# Altium schematic sheet cny70.SchDoc(cny70)
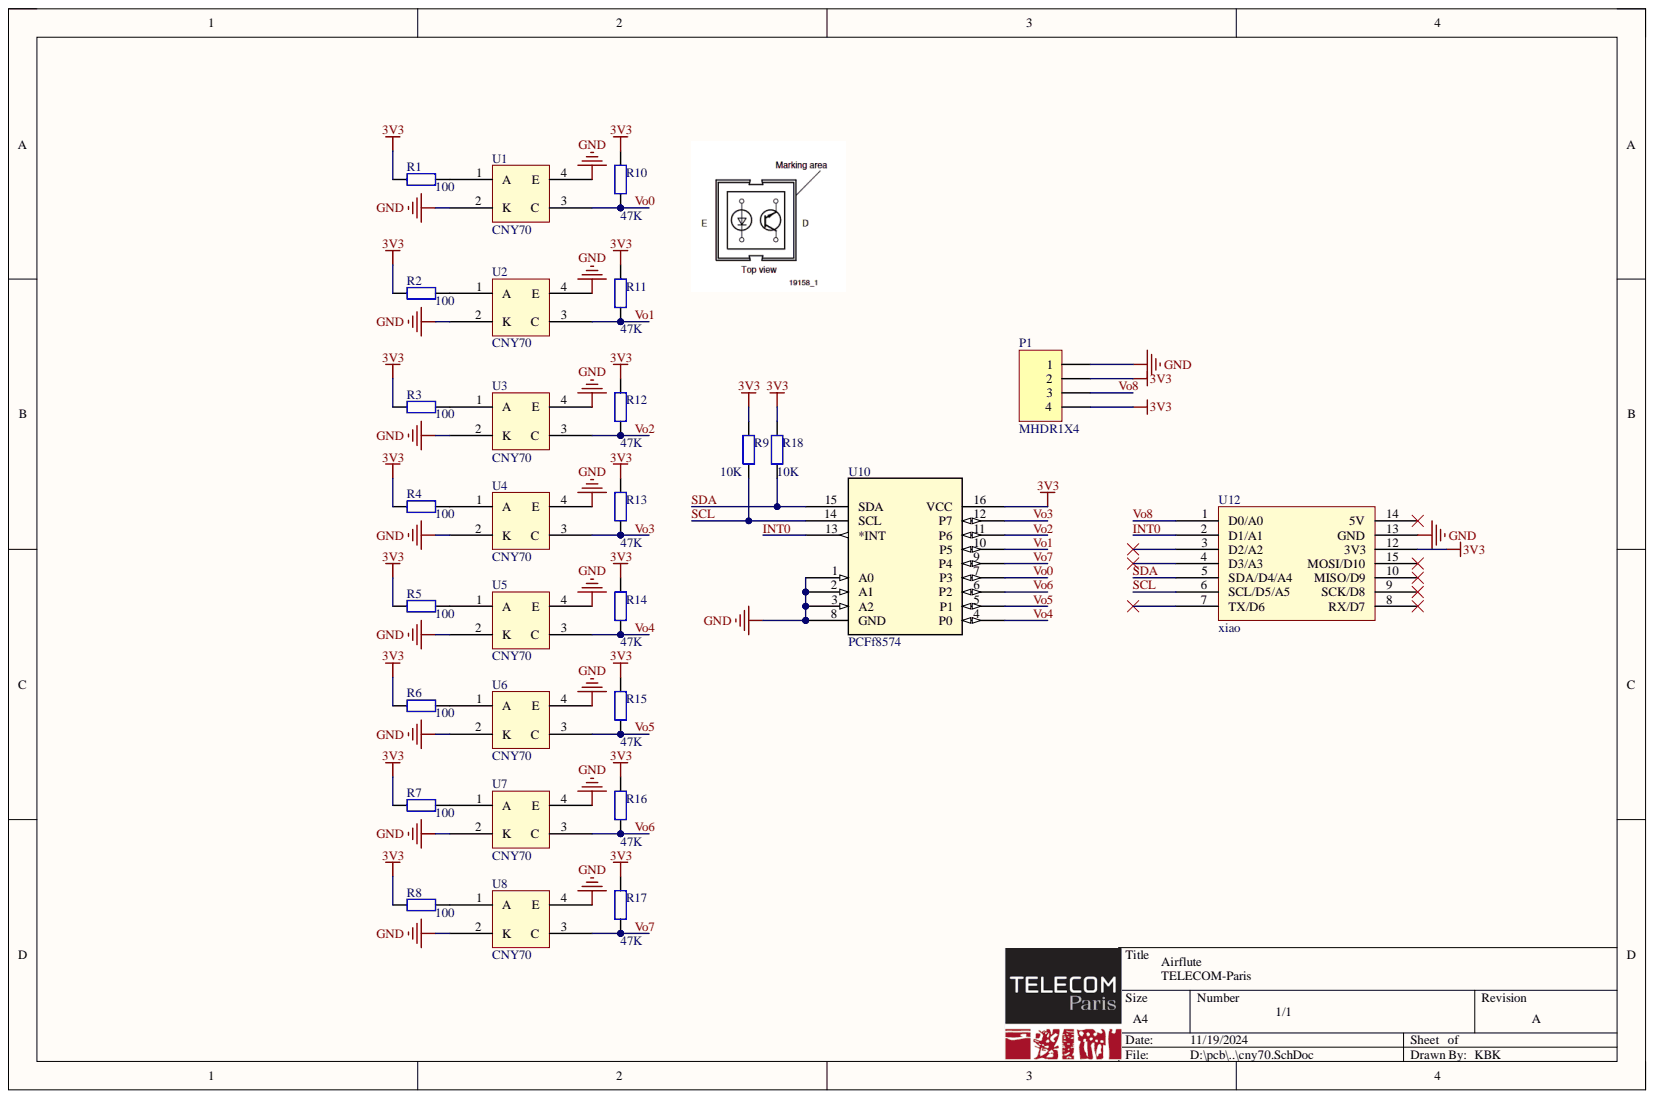
[diagram p0: cny70.SchDoc]

## Parts
P1  MHDR1X4  [HDR1X4]
R1  value 100  [6-0805_L]  (hidden comment: Res100)
R2  value 100  [6-0805_L]  (hidden comment: Res100)
R3  value 100  [6-0805_L]  (hidden comment: Res100)
R4  value 100  [6-0805_L]  (hidden comment: Res100)
R5  value 100  [6-0805_L]  (hidden comment: Res100)
R6  value 100  [6-0805_L]  (hidden comment: Res100)
R7  value 100  [6-0805_L]  (hidden comment: Res100)
R8  value 100  [6-0805_L]  (hidden comment: Res100)
R9  value 10K  [6-0805_L]  (hidden comment: Res10k)
R10  value 47K  [6-0805_L]  (hidden comment: Res4.7k)
R11  value 47K  [6-0805_L]  (hidden comment: Res4.7k)
R12  value 47K  [6-0805_L]  (hidden comment: Res4.7k)
R13  value 47K  [6-0805_L]  (hidden comment: Res4.7k)
R14  value 47K  [6-0805_L]  (hidden comment: Res4.7k)
R15  value 47K  [6-0805_L]  (hidden comment: Res4.7k)
R16  value 47K  [6-0805_L]  (hidden comment: Res4.7k)
R17  value 47K  [6-0805_L]  (hidden comment: Res4.7k)
R18  value 10K  [6-0805_L]  (hidden comment: Res10k)
U1  CNY70  [ncy70]
U2  CNY70  [ncy70]
U3  CNY70  [ncy70]
U4  CNY70  [ncy70]
U5  CNY70  [ncy70]
U6  CNY70  [ncy70]
U7  CNY70  [ncy70]
U8  CNY70  [ncy70]
U10  PCFf8574  [DW016_M]
U12  xiao  [xiao]

## Nets
3V3: P1.2 P1.4 R1.2 R2.2 R3.2 R4.2 R5.2 R6.2 R7.2 R8.2 R9.2 R10.2 R11.2 R12.2 R13.2 R14.2 R15.2 R16.2 R17.2 R18.2 U10.16(VCC) U12.12(3V3)
GND: P1.1 U1.2(K) U1.4(E) U2.2(K) U2.4(E) U3.2(K) U3.4(E) U4.2(K) U4.4(E) U5.2(K) U5.4(E) U6.2(K) U6.4(E) U7.2(K) U7.4(E) U8.2(K) U8.4(E) U10.1(A0) U10.2(A1) U10.3(A2) U10.8(GND) U12.13(GND)
INT0: U10.13(*INT) U12.2(D1/A1)
SCL: R9.1 U10.14(SCL) U12.6(SCL/D5/A5)
SDA: R18.1 U10.15(SDA) U12.5(SDA/D4/A4)
Vo0: R10.1 U1.3(C) U10.7(P3)
Vo1: R11.1 U2.3(C) U10.10(P5)
Vo2: R12.1 U3.3(C) U10.11(P6)
Vo3: R13.1 U4.3(C) U10.12(P7)
Vo4: R14.1 U5.3(C) U10.4(P0)
Vo5: R15.1 U6.3(C) U10.5(P1)
Vo6: R16.1 U7.3(C) U10.6(P2)
Vo7: R17.1 U8.3(C) U10.9(P4)
Vo8: P1.3 U12.1(D0/A0)
NetR1_1: R1.1 U1.1(A)
NetR2_1: R2.1 U2.1(A)
NetR3_1: R3.1 U3.1(A)
NetR4_1: R4.1 U4.1(A)
NetR5_1: R5.1 U5.1(A)
NetR6_1: R6.1 U6.1(A)
NetR7_1: R7.1 U7.1(A)
NetR8_1: R8.1 U8.1(A)

Not connected: U12 3(D2/A2) 4(D3/A3) 7(TX/D6) 8(RX/D7) 9(SCK/D8) 10(MISO/D9) 14(5V) 15(MOSI/D10)

## Library data of the parts
P1 = MHDR1X4: Header, 4-Pin; Component Kind: Standard; LatestRevisionDate: 17-Jul-2002; LatestRevisionNote: Re-released for DXP Platform.; Library Name: Miscellaneous Connectors.IntLib; Mouser: 200-HTSW10408GSRA; Signal Integrity: Connector; Publisher: Altium Limited
R1-R18 = Res2: Resistor; Component Kind: Standard; LatestRevisionDate: 17-Jul-2002; LatestRevisionNote: Re-released for DXP Platform.; Library Name: Miscellaneous Devices_open.SchLib; PackageDescription: Axial Device, Thru-Hole; 2 Leads; 0.4 in Pin Spacing; PackageReference: AXIAL-0.4; Published: 8-Jun-2000; Signal Integrity: Res; Simulation: RESISTOR; Publisher: Altium Limited
  R1-R8: Mouser: WR08X1000FTL
  R9 R18: Mouser: RC0805JR-1310KL
  R10-R17: Mouser: CRGH0805F4K7
U1-U5 U7 U8 = CNY70: Reflective Optical Senso; Component Kind: Standard; Library Name: Backup of lib_Sch.Lib; Mouser: 782-CNY70
U10 = pcf8574: Remote 8-Bit I/O Expander for I2C; Component Kind: Standard; Library Name: Backup of lib_Sch.Lib; Mouser: 595-PCF8574APWR
U12 = xiao: ESP32-S3 is a low-power MCU-based system; Component Kind: Standard; Library Name: Backup of lib_Sch.Lib; Mouser: 713-113991054
U6 = CNY70: Component Kind: Standard; Library Name: Backup of lib_Sch.Lib; Mouser: 782-CNY70
